# Altium schematic sheet Touch sensor.SchDoc
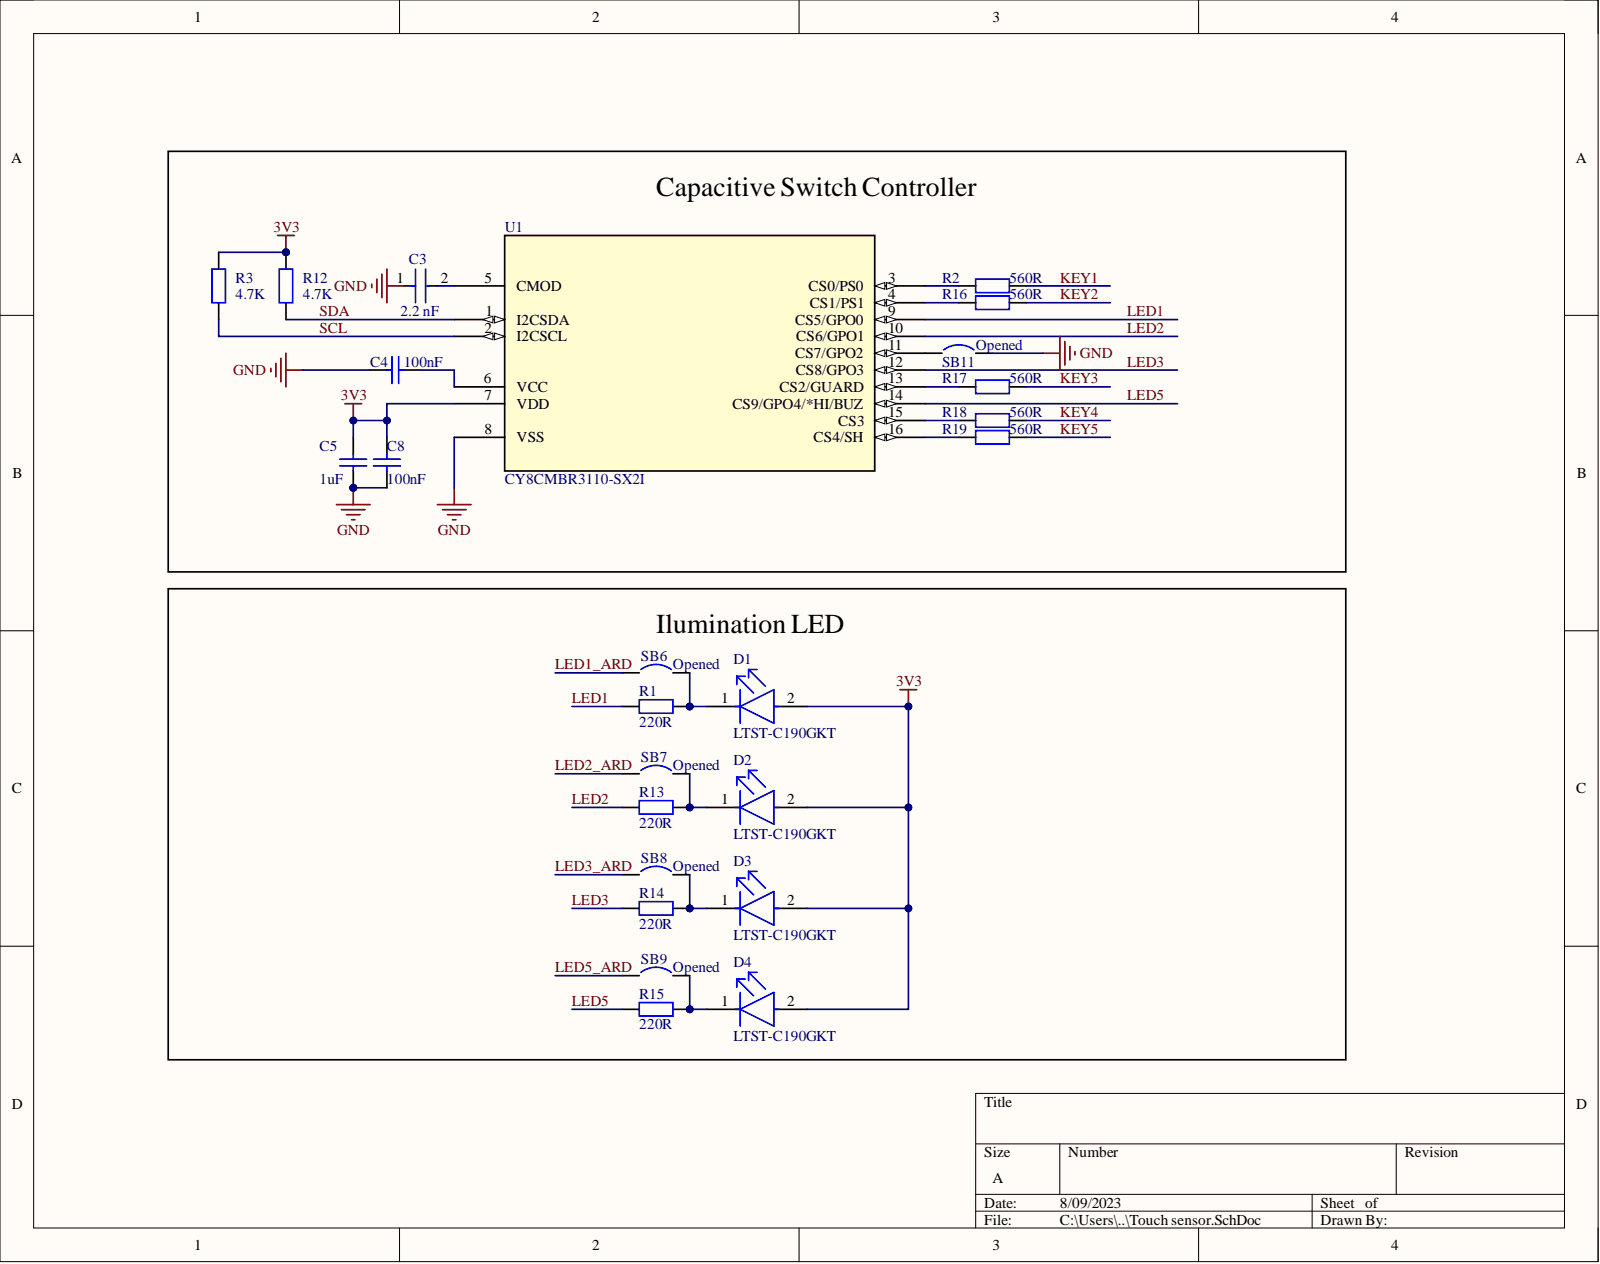
[diagram p0: Touch sensor.SchDoc]

## Parts
C3  value 2.2 nF  Capacitance: 2.2 nF  [FP-CC0402-MFG]  (hidden comment: CC0402KRX7R9BB222)
C4  value 100nF  [c0402]  (hidden comment: Cap Semi)
C5  1uF  [C0402]
C8  value 100nF  [c0402]  (hidden comment: Cap Semi)
D1  LTST-C190GKT  [FP-LTST-C190GKT-MFG]
D2  LTST-C190GKT  [FP-LTST-C190GKT-MFG]
D3  LTST-C190GKT  [FP-LTST-C190GKT-MFG]
D4  LTST-C190GKT  [FP-LTST-C190GKT-MFG]
R1  220R  [R0402]
R2  560R  [R0402]
R3  4.7K  [R0402]
R12  4.7K  [R0402]
R13  220R  [R0402]
R14  220R  [R0402]
R15  220R  [R0402]
R16  560R  [R0402]
R17  560R  [R0402]
R18  560R  [R0402]
R19  560R  [R0402]
SB6  Opened  [SB_0402 - open]  (no BOM)
SB7  Opened  [SB_0402 - open]  (no BOM)
SB8  Opened  [SB_0402 - open]  (no BOM)
SB9  Opened  [SB_0402 - open]  (no BOM)
SB11  Opened  [SB_0402 - open]  (no BOM)
U1  CY8CMBR3110-SX2I  [SOIC-16]

## Nets
3V3: C5.2 C8.2 D1.2 D2.2 D3.2 D4.2 R3.2 R12.1 U1.7(VDD)
GND: C3.1 C4.2 C5.1 C8.1 SB11.1 U1.8(VSS)
KEY1: R2.2
KEY2: R16.2
KEY3: R17.2
KEY4: R18.2
KEY5: R19.2
LED1: R1.1 U1.9(CS5/GPO0)
LED1_ARD: SB6.2
LED2: R13.1 U1.10(CS6/GPO1)
LED2_ARD: SB7.2
LED3: R14.1 U1.12(CS8/GPO3)
LED3_ARD: SB8.2
LED5: R15.1 U1.14(CS9/GPO4/*HI/BUZ)
LED5_ARD: SB9.2
SCL: R3.1 U1.2(I2CSCL)
SDA: R12.2 U1.1(I2CSDA)
NetC3_2: C3.2 U1.5(CMOD)
NetC4_1: C4.1 U1.6(VCC)
NetD1_1: D1.1 R1.2 SB6.1
NetD2_1: D2.1 R13.2 SB7.1
NetD3_1: D3.1 R14.2 SB8.1
NetD4_1: D4.1 R15.2 SB9.1
NetR2_1: R2.1 U1.3(CS0/PS0)
NetR16_1: R16.1 U1.4(CS1/PS1)
NetR17_1: R17.1 U1.13(CS2/GUARD)
NetR18_1: R18.1 U1.15(CS3)
NetR19_1: R19.1 U1.16(CS4/SH)
NetSB11_2: SB11.2 U1.11(CS7/GPO2)

## Library data of the parts
C3 = CMP-1034-01742-2: CAP CER 2200PF 50V X7R 0402; Manufacturer: YAGEO; Part Description: Ceramic Capacitor; Automotive Grade: NA; Material: Ceramic; Specification: X7R, 50V, 10%; Temperature Coefficient: 30ppm/°C; Tolerance: 0.1; Automotive: No; Category: Cap; Component Kind: Standard; ComponentLink2Description: Datasheet; ComponentLink2URL: http://www.yageo.com/documents/recent/UPY-GP_NP0_16V-to-50V_16.pdf; Device Class L1: Passive Components; Device Class L2: Capacitors; Device Class L3: Ceramic Capacitors; Digikey Description: CAP CER 2200PF 50V X7R 0402; Footprint Url: http://www.yageo.com/exep/pages/download/literatures/UPY-C_GEN_24.pdf; Height: 0.55mm; Ipc Land Pattern Name: CAPC10050X50; Lead Free: Yes; Library Name: Altium Content Vault; Link: https://www.rutronik24.com/search-result/qs:CC0402KRX7R9BB222/reset:0; Manufacturer Part No.: CC0402KRX7R9BB222; Manufacturer Part Number: CC0402KRX7R9BB222; Mouser Description: Multilayer Ceramic Capacitors MLCC - SMD/SMT 2.2nF 50V X7R 10%; Octopart: https://octopart.com/cc0402krx7r9bb222-yageo-39467642; Package: CC0402; RoHS: TRUE; Supplier: Rutronik; Supplier part number: KKK12781; Temperature: -55°C to +125°C; Temperature Characteristic: X7R; Temperature Range High: +125°C; Temperature Range Low: -55°C; Voltage Rating: 50 V
C4 C8 = Cap Semi: Capacitor (Semiconductor SIM Model); Manufacturer: YAGEO; Manufacturer Part No.: CC0402KRX7R9BB104; Part Description: Ceramic Capacitor; Specification: X7R, 50V, 10%; Component Kind: Standard; Library Name: Miscellaneous Devices.IntLib; Link: https://www.rutronik24.com/search-result/qs:KKK23619/reset:0; Signal Integrity: Cap Semi; Simulation: CAP; Supplier: Rutronik; Supplier part number: KKK23619
C5 = Cap Semi: Capacitor (Semiconductor SIM Model); Manufacturer: muRata; Manufacturer Part No.: GRM155R61E105KA12D; Part Description: Ceramic Capacitor; Specification: X5R, 25V, 10%; Component Kind: Standard; Library Name: Miscellaneous Devices.IntLib; Link: https://www.rutronik24.com/product/murata/grm155r61e105ka12d/4725132.html; Signal Integrity: Cap Semi; Simulation: CAP; Supplier: Rutronik; Supplier part number: KKS4987; Value: 1uF
D1-D4 = CMP-22017-000005-2: LED GREEN CLEAR CHIP SMD; Manufacturer: OSRAM AG; Manufacturer Part No.: LWQ38G-Q1OO-3K6L-1; Part Description: CHIPLED 0603 WHITE 71mcd; Dominant Wavelength: 569nm; Forward Current: 30mA; Forward Voltage: 2.1V; Lens Color: Colorless; Luminous Intensity: 6mcd; Number of Elements: 1; Power Dissipation: 100mW; Reverse Voltage: 5V; Test Current: 10mA; Viewing Angle: 130°; Voltage: 2V; Wavelength: 565nm; Case Code (Imperial): 0603; Case Code (Metric): 1608; Case/Package: 0603; Color: Green; Component Kind: Standard; Depth: 0.8mm; Height: 0.8mm; Illumination Color: Green; Lead Free: Lead Free; Length: 1.6mm; Lens Style: Clear; Lens Transparency: Clear; Library Name: Altium Content Vault; Link: https://www.rutronik24.com/product/osram/lwq38g-q1oo-3k6l-1/33274.html; Max Operating Temperature: 85°C; Min Operating Temperature: -55°C; Mount: Surface Mount; Number of LEDs: 1; Number of Pins: 2; Package Quantity: 1; Packaging: Tape and Reel; Power Rating: 100mW; Radiation Hardening: No; Supplier part number: LED13433; Width: 0.8mm
R1 R13-R15 = Res2: Manufacturer: ASJ; Manufacturer Part No.: CR10-221-JK; Part Description: Thick Film Resistor; Specification: 5% 0,063W; Component Kind: Standard; Library Name: Miscellaneous Devices.IntLib; Link: https://www.rutronik24.com/product/asj/cr10-221-jk/51883.html; Signal Integrity: Res; Simulation: RESISTOR; Supplier: Rutronik; Supplier part number: WRC35108; Value: 220R
R2 R16-R19 = Res2: Manufacturer: ASJ; Manufacturer Part No.: CR10-561-JK; Part Description: Thick Film Resistor; Specification: 5% 0,063W; Component Kind: Standard; Library Name: Miscellaneous Devices.IntLib; Link: https://www.rutronik24.com/product/asj/cr10-561-jk/57498.html; Signal Integrity: Res; Simulation: RESISTOR; Supplier: Rutronik; Supplier part number: WRC49106; Value: 560R
R3 R12 = Res2: Manufacturer: ASJ; Manufacturer Part No.: CR10-472-JK; Part Description: Thick Film Resistor; Specification: 5% 0,063W; Component Kind: Standard; Library Name: Miscellaneous Devices.IntLib; Link: https://www.rutronik24.com/product/asj/cr10-472-jk/54393.html; Signal Integrity: Res; Simulation: RESISTOR; Supplier: Rutronik; Supplier part number: WRC41427; Value: 4.7K
SB6-SB9 SB11 = Circuit Breaker_close: Circuit Breaker; Manufacturer: Yageo; Manufacturer Part No.: AC0402FR-070RL; Part Description: Thick Film Resistor; Specification: 5% 0,063W; Value: 0R; Link: https://www.rutronik24.com/product/yageo/ac0402fr-070rl/1082692.html; Revision: July-2002: Re-released for DXP Platform.; Supplier: Rutronik; Supplier part number: WRC52534; Library Name: RutAppKit-LedDriverSensor.SCHLIB
U1 = CY8CMBR3110-SX2I: No Description Available; Manufacturer: Cypress; Part Description: CapSense MBR3 10-inputs; Built_By: EMA_Jessica; SourceLibrary: Cypress_Semiconductor_2019-12-17; Vendor: Cypress Semiconductor; Component Kind: Standard; Library Name: 2021-06-18_10-27-34.SchLib; Link: https://www.rutronik24.com/product/cypress/cy8cmbr3110-sx2i/14190309.html; Manufacturer Part No.: CY8CMBR3110-SX2I; Manufacturer_Part_Number: CY8CMBR3110-SX2I; RefDes: RefDes; Supplier: Rutronik; Supplier part number: ICPER6861; Type: DEV; Copyright: Copyright (C) 2018 Accelerated Designs. All rights reserved
